# Altium schematic sheet LEDs.SchDoc(LEDs)
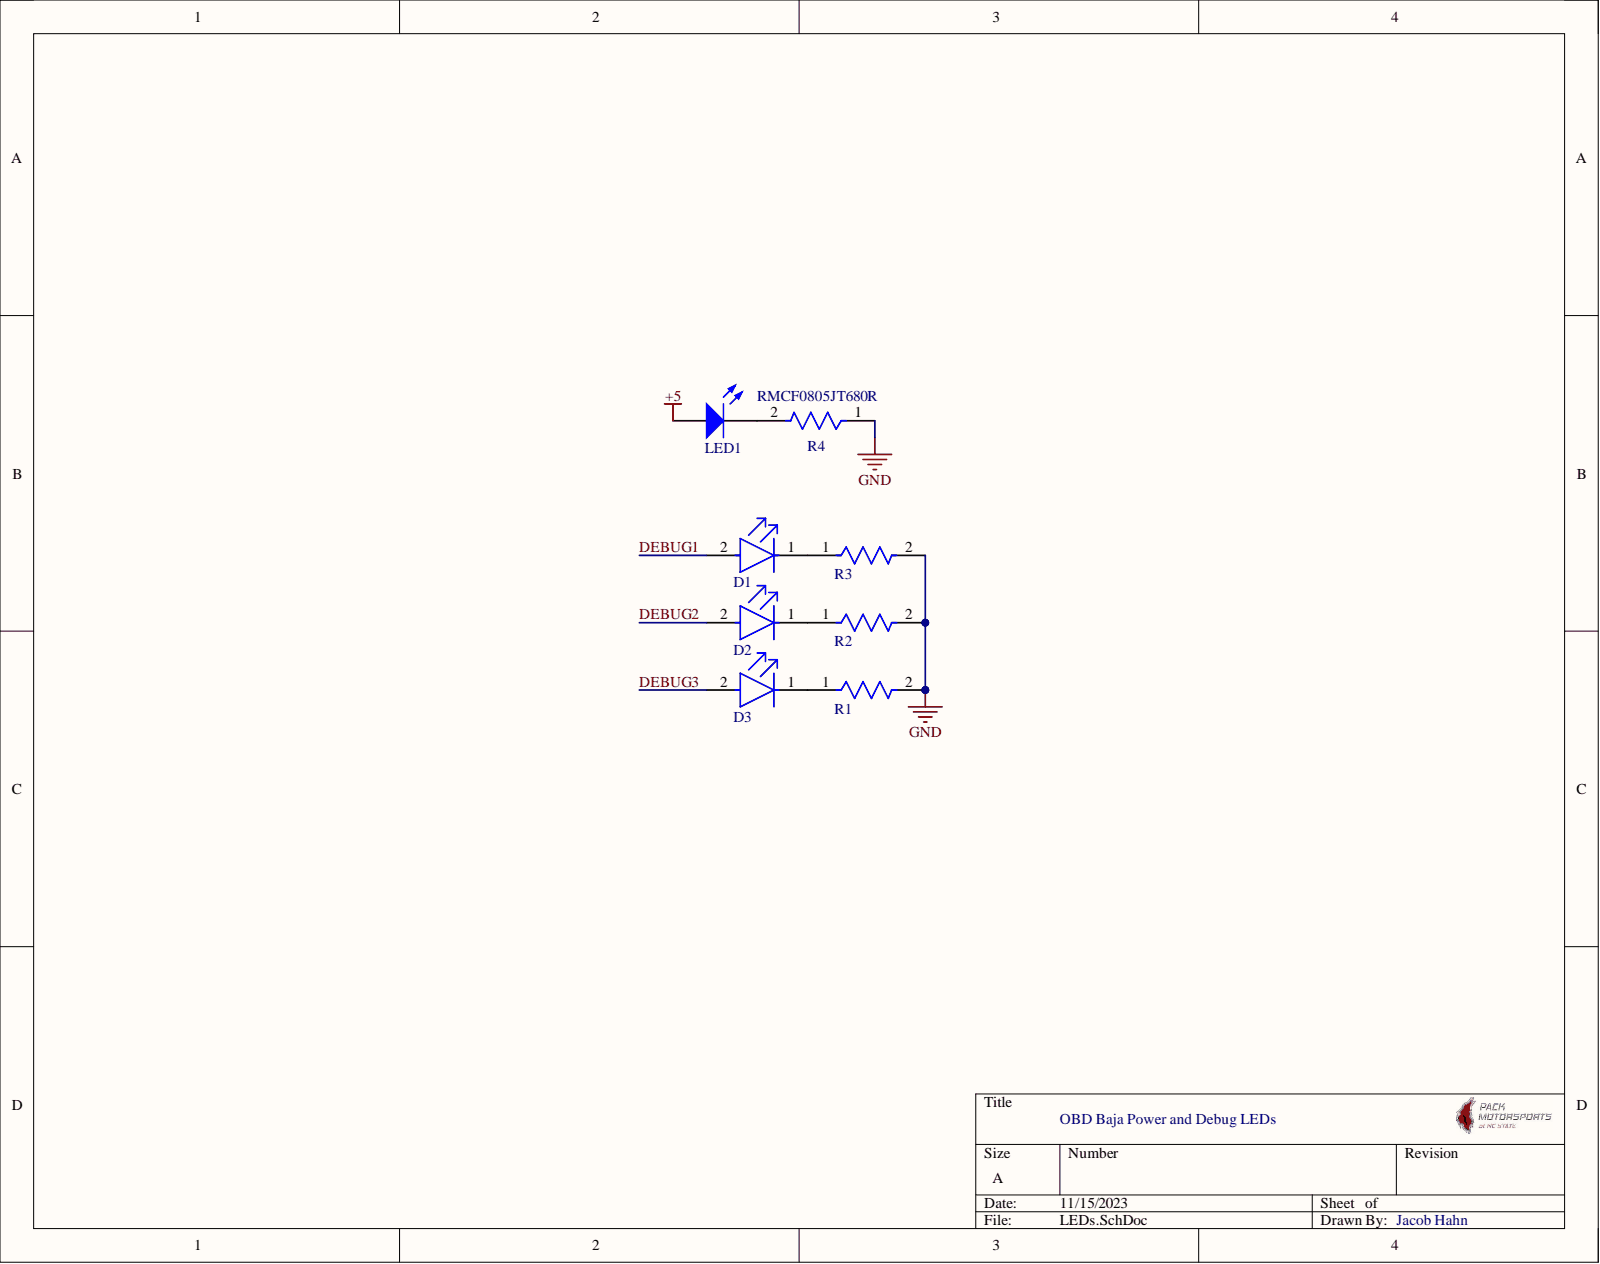
[diagram p0: LEDs.SchDoc]

## Parts
D1  LTST-C170GKT  (value not drawn)
D2  LTST-C170GKT  (value not drawn)
D3  LTST-C170GKT  (value not drawn)
LED1  151031SS04000  (value not drawn)
R1  RMCF0805JT240R  (value not drawn)
R2  RMCF0805JT240R  (value not drawn)
R3  RMCF0805JT240R  (value not drawn)
R4  RMCF0805JT680R

## Nets
+5: LED1.2
GND: R1.2 R2.2 R3.2 R4.1
DEBUG1: D1.2
DEBUG2: D2.2
DEBUG3: D3.2
NetD1_1: D1.1 R3.1
NetD2_1: D2.1 R2.1
NetD3_1: D3.1 R1.1
NetLED1_1: LED1.1 R4.2

## Library data of the parts
D1-D3 = CMP-1674-00002-6: LED GREEN CLEAR SMD; Dominant Wavelength: 569nm; Forward Current: 30mA; Forward Voltage: 2.1V; Lens Color: Colorless; Lens Style: Diffused; Luminous Intensity: 6mcd; Number of Elements: 1; Power Dissipation: 100mW; Reverse Voltage: 5V; Test Current: 10mA; Viewing Angle: 130°; Wavelength: 565nm; Case Code (Imperial): 0805; Case Code (Metric): 2012; Case/Package: 0805; Color: Green; Component Kind: Standard; Depth: 1.25mm; Height: 1.1mm; Illumination Color: Green; Lead Free: Lead Free; Length: 2mm; Lens Transparency: Clear; Library Name: Altium Content Vault; Max Operating Temperature: 85°C; Min Operating Temperature: -55°C; Mount: Surface Mount; Number of LEDs: 1; Number of Pins: 2; Package Quantity: 1; Packaging: Tape and Reel; Power Rating: 100mW; Radiation Hardening: No; Voltage: 5V; Width: 1.25mm
LED1 = CMP-1488-00005-1: THT LED round mono-color color lens, WL-TMRW, Super Red; Manufacturer: Wuerth Elektronik; Component Kind: Standard; ComponentLink1Description: Manufacturer URL; ComponentLink1URL: http://www.we-online.com; ComponentLink2Description: Datasheet; ComponentLink2URL: http://katalog.we-online.de/pbs/datasheet/7448042001.pdfhttp://katalog.we-online.de/pbs/datasheet/7448042001.pdfhttp://katalog.we-online.de/pbs/datasheet/7448042001.pdfhttp://katalog.we-online.de/pbs/datasheet/151031SS04000.pdf; Emitting color: Super Red; Library Name: Altium Content Vault; PackageReference: Type 3mm; PartNumber: 151031SS04000
R1-R3 = CMP-26527-005851-1: 240Ω ±5% 0.125W 0805 Thick Film Chip Resistor AEC-Q200 compliant; Max Power Dissipation: 125mW; Resistance: 240R; Temperature Coefficient: 200ppm/°C; Case Code (Imperial): 0805; Case Code (Metric): 2012; Case/Package: 0805; Component Kind: Standard; Contact Plating: Tin; Features: Automotive AEC-Q200; Height: 0.026inch; Length: 0.079inch; Library Name: Altium Content Vault; Max Operating Temperature: 155°C; Min Operating Temperature: -55°C; Mount: Surface Mount; Number of Terminations: 2; Packaging: Tape and Reel; Radiation Hardening: No; Resistor Type: Thick Film; RoHS Compliant: Yes; Series: RMCF; Tolerance: 5%; Width: 0.049inch
R4 = CMP-26527-000032-1: 680Ω ±5% 0.125W 0805 Thick Film Chip Resistor AEC-Q200 compliant; Max Power Dissipation: 125mW; Resistance: 680R; Temperature Coefficient: 200ppm/°C; Case Code (Imperial): 0805; Case Code (Metric): 2012; Case/Package: 0805; Component Kind: Standard; Construction: Rectangular; Contact Plating: Tin; Depth: 1.25mm; Features: Automotive AEC-Q200; Height: 0.026inch; Lead Free: Lead Free; Length: 2mm; Library Name: Altium Content Vault; Max Operating Temperature: 155°C; Military Standard: Not; Min Operating Temperature: -55°C; Mount: Surface Mount; Number of Terminations: 2; Packaging: Tape and Reel; Radiation Hardening: No; Resistor Type: Thick Film; RoHS Compliant: Yes; Series: RMCF; Tolerance: 5%; Width: 0.049inch
